# Altium schematic sheet pay-ssm.SchDoc(pay-ssm)
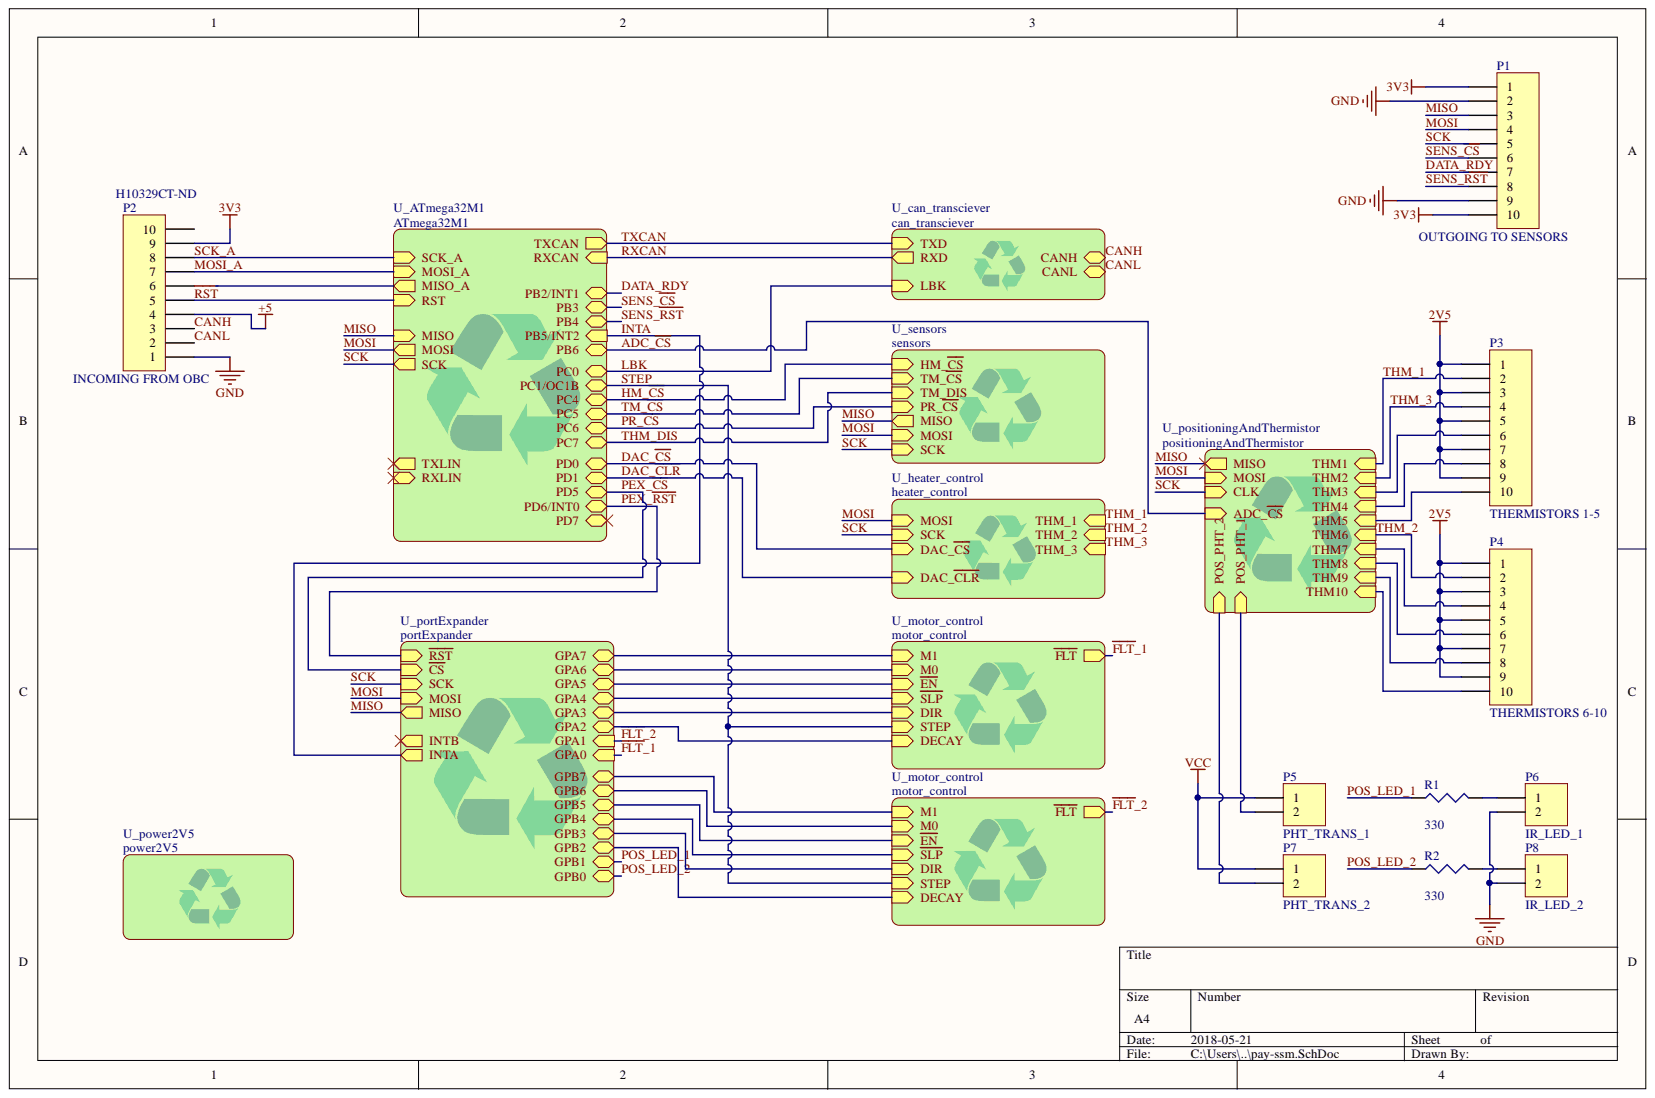
[diagram p0: pay-ssm.SchDoc]

## Parts
P1  OUTGOING TO SENSORS  [HDR1X10]
P2  INCOMING FROM OBC  Supplier Part Number: H10329CT-ND  [HDR1X10]
P3  THERMISTORS 1-5  [HDR1X10]
P4  THERMISTORS 6-10  [HDR1X10]
P5  PHT_TRANS_1  [HDR1X2]
P6  IR_LED_1  [HDR1X2]
P7  PHT_TRANS_2  [HDR1X2]
P8  IR_LED_2  [HDR1X2]
R1  value 330  [J1-0603]  (hidden comment: Res3)
R2  value 330  [J1-0603]  (hidden comment: Res3)

## Nets
2V5: P3.1 P3.3 P3.5 P3.7 P3.9 P4.1 P4.3 P4.5 P4.7 P4.9
3V3: P1.1 P1.10 P2.9
+5: P2.4
GND: P1.2 P1.9 P2.1 P6.2 P8.2
VCC: P5.1 P7.1
CANH: P2.3
CANL: P2.2
DATA_RDY: P1.7
MISO: P1.3
MOSI: P1.4
MOSI_A: P2.7
POS_LED_1: R1.1
POS_LED_2: R2.1
~{RST}: P2.5
SCK: P1.5
SCK_A: P2.8
SENS_~{CS}: P1.6
SENS_~{RST}: P1.8
THM_1: P3.2
THM_2: P4.2
THM_3: P3.4
NetP2_6: P2.6
NetP2_10: P2.10
NetP3_6: P3.6
NetP3_8: P3.8
NetP3_10: P3.10
NetP4_4: P4.4
NetP4_6: P4.6
NetP4_8: P4.8
NetP4_10: P4.10
NetP5_2: P5.2
NetP6_1: P6.1 R1.2
NetP7_2: P7.2
NetP8_1: P8.1 R2.2
ADC_~{CS}:
DAC_~{CLR}:
DAC_~{CS}:
DECAY:
DIR:
~{EN}:
~{FLT}_1:
~{FLT}_2:
HM_~{CS}:
INTA:
INTB:
LBK:
M0:
M1:
MISO_A:
PD7:
PEX_~{CS}:
PEX_~{RST}:
PR_~{CS}:
RXCAN:
RXLIN:
~{SLP}:
STEP:
THM_DIS:
TM_~{CS}:
TXCAN:
TXLIN:

## Library data of the parts
P1-P4 = Header 10: Header, 10-Pin; Signal Integrity: Connector; Library Name: Miscellaneous Connectors.IntLib; LatestRevisionNote: Re-released for DXP Platform.; LatestRevisionDate: 17-Jul-2002; Component Kind: Standard; Publisher: Altium Limited
  P2: Supplier: Digi-Key
P5-P8 = Header 2: Header, 2-Pin; Signal Integrity: Connector; Library Name: Miscellaneous Connectors.IntLib; LatestRevisionNote: Re-released for DXP Platform.; LatestRevisionDate: 17-Jul-2002; Component Kind: Standard; Publisher: Altium Limited
R1 R2 = Res3: Resistor; Simulation: RESISTOR; Signal Integrity: Res; Published: 8-Jun-2000; PackageReference: J1-0603; PackageDescription: Chip Resistor; Library Name: Miscellaneous Devices.IntLib; LatestRevisionNote: IPC-7351 Footprint Added.; LatestRevisionDate: 29-May-2009; Component Kind: Standard; Publisher: Altium Limited
